# Altium schematic sheet USB_Input.SchDoc
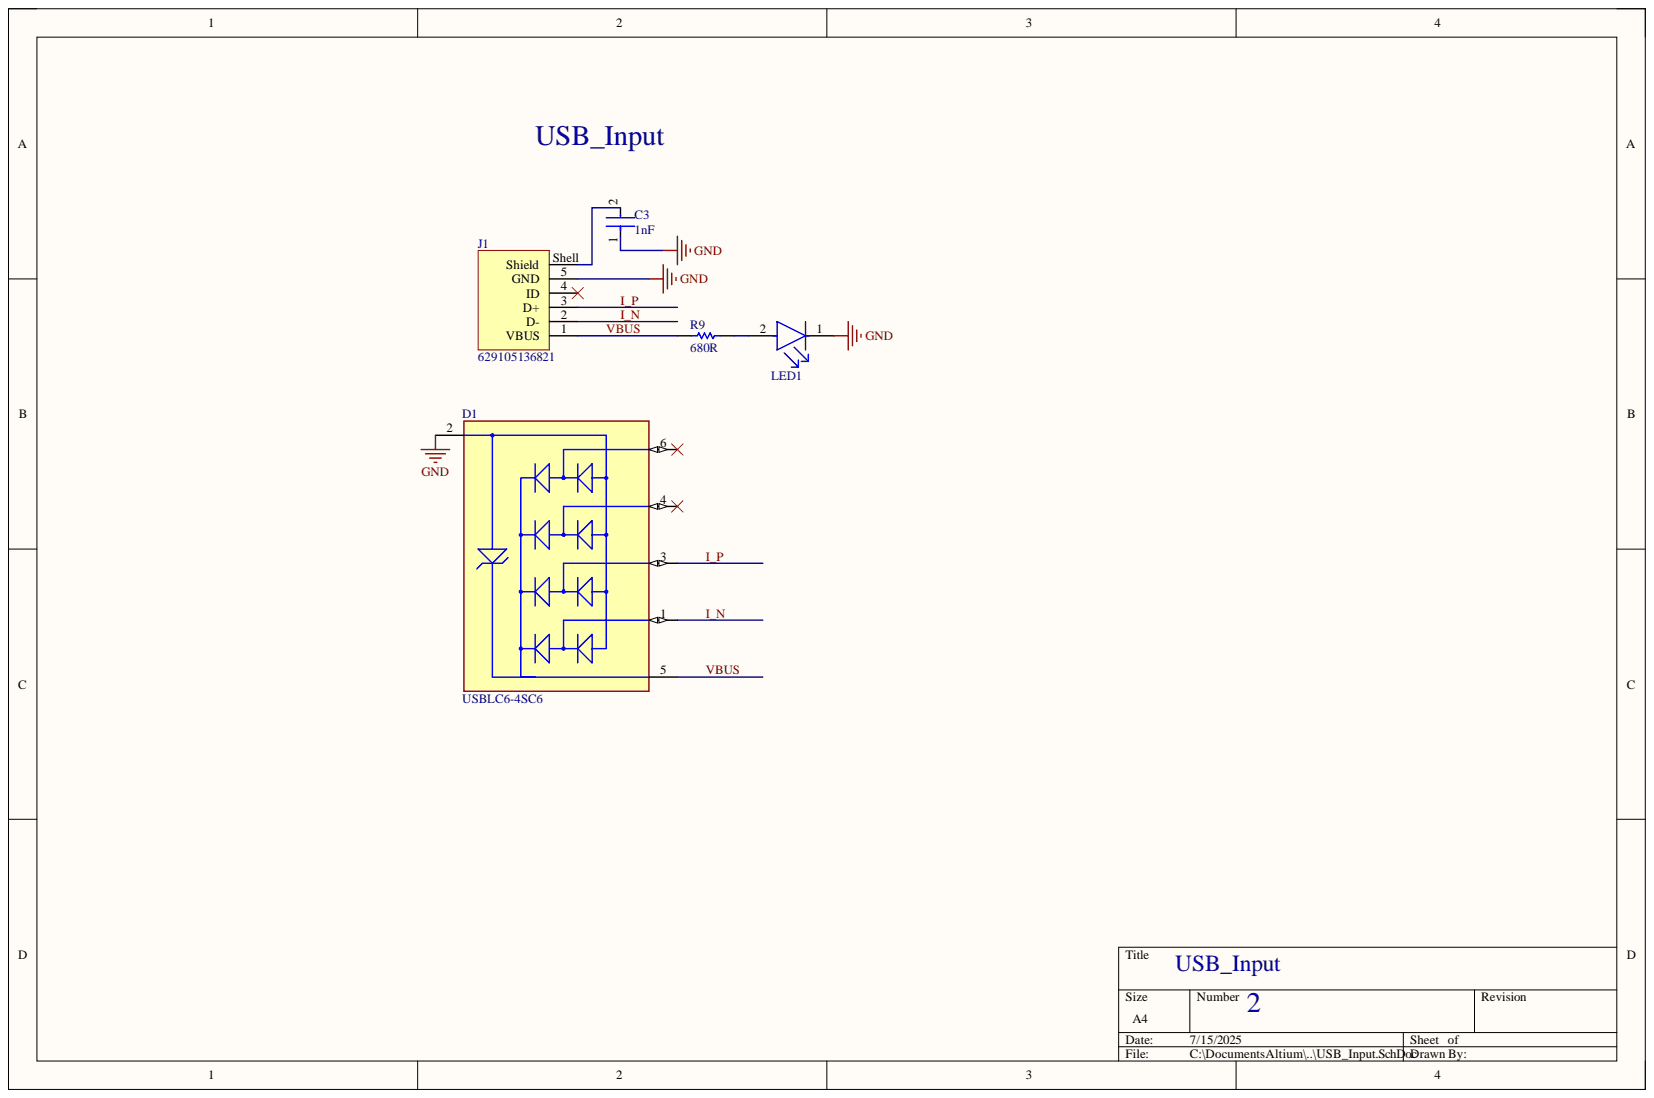
[diagram p0: USB_Input.SchDoc]

## Parts
C3  1nF  [FP-CC0603-DA-MFG]
D1  USBLC6-4SC6  [FP-SOT23-6L-MFG]
J1  629105136821  [629105136821]
LED1  LS R976-NR-1  [FP-LS_R976-MFG]  (value not drawn)
R9  680R  [RESC1608X55X30ML20T20]

## Nets
GND: C3.1 D1.2 J1.5(GND) LED1.1
I_N: D1.1 J1.2(D-)
I_P: D1.3 J1.3(D+)
VBUS: D1.5 J1.1(VBUS) R9.2
NetC3_2: C3.2 J1.Shell(Shield)
NetLED1_2: LED1.2 R9.1

Not connected: D1 4 6; J1 4(ID)

## Library data of the parts
C3 = CMP-2100-03599-2: CAP CER 1000PF 50V X7R 0603; Manufacturer: YAGEO; Manufacturer Part Number: CC0603KRX7R9BB102; Capacitance: 1.0 nF; Material: Ceramic; Temperature Coefficient: 15%; Tolerance: 0.1; Value: 1.0 nF; Automotive: No; Category: Cap; Component Kind: Standard; ComponentLink2Description: Datasheet; ComponentLink2URL: http://www.yageo.com/documents/recent/UPY-GPHC_X7R_6.3V-to-50V_18.pdf; Device Class L1: Passive Components; Device Class L2: Capacitors; Device Class L3: Ceramic Capacitors; Digikey Description: CAP CER 1000PF 50V X7R 0603; Footprint Url: http://www.yageo.com/exep/pages/download/literatures/UPY-C_GEN_24.pdf; Height: 0.9mm; Lead Free: Yes; Library Name: Altium Content Vault; Mouser Description: Multilayer Ceramic Capacitors MLCC - SMD/SMT 1.0nF 50V X7R 10%; Octopart: https://octopart.com/cc0603krx7r9bb102-yageo-192933; Package: CC0603-DA; RoHS: TRUE; Temperature: -55°C to +125°C; Temperature Characteristic: X7R; Temperature Range High: +125°C; Temperature Range Low: -55°C; Voltage: 50 V; Voltage Rating: 50 V
D1 = CMP-2000-07370-2: TVS DIODE 5.25V 17V SOT23-6; Manufacturer: STMicroelectronics; Breakdown Voltage: 6V; Capacitance: 3pF; Direction: Unidirectional; Interface: USB,Ethernet,Other; Max Junction Temp: +125°C; Number Of Channels: 4; Peak Pulse Power: 130W; Standoff Height: 0mm; Value: 3pF; Automotive: No; Category: Diode; Clamping Voltage: 17V; Component Kind: Standard; ComponentLink2Description: Datasheet; ComponentLink2URL: https://upverter.com/datasheet/23dc4ffb028d868909e80577610284b194f13213.pdf; Device Class L1: Circuit Protection; Device Class L2: ESD and Circuit Protection ICs; Device Class L3: unset; Digikey Description: TVS DIODE 5.25V 17V SOT23-6; Height: 1.45mm; Lead Free: Yes; Library Name: Altium Content Vault; Manufacturer Part Number: USBLC6-4SC6; Mouser Description: USBLC6 Series 4 Line 6 V Uni / Bi-Directional ESD Protection - SOT-23-6; Octopart: https://octopart.com/usblc6-4sc6-stmicroelectronics-347147; Package: SOT23-6L; RoHS: TRUE; Temperature: -40°C to +125°C; Temperature Range High: +125°C; Temperature Range Low: -40°C
J1 = CMP-1502-03253-2: NexarPartId: 19991173; Connector Type: USB; Contact Resistance: 30mΩ; Current Rating: 1A; Gender: Receptacle; Insulation Resistance: 1GΩ; Number of Contacts: 5; Number of Ports: 1; Operating Supply Voltage: 30V; Plating: Nickel; USB Standard: USB 2.0; Color: Black; Component Kind: Standard; Contact Material: Copper; Contact Plating: Gold; Flammability Rating: UL94 V-0; Height: 2.9mm; Lead Free: Lead Free; Length: 5.9mm; Library Name: Altium Content Vault; Max Operating Temperature: 105°C; Min Operating Temperature: -40°C; Mount: Horizontal, Surface Mount; Number of Pins: 5; Number of Positions: 5; Orientation: Horizontal; Packaging: Tape and Reel; REACH SVHC: Unknown; RoHS: Compliant; Termination: Solder; Voltage Rating: 30V
LED1 = CMP-62016-000037-1: LED RED DIFFUSED 0805 SMD; Dominant Wavelength: 633nm; Forward Current: 25mA; Forward Voltage: 2.5V; Lens Color: White; Lens Size: 1.25mm; Lens Style: Flat; Luminous Flux: 0.47lm; Luminous Intensity: 180mcd; Number of Elements: 1; Power Dissipation: 65W; Reverse Voltage: 12V; Test Current: 20mA; Viewing Angle: 160°; Case Code (Imperial): 0805; Case Code (Metric): 2012; Case/Package: 0805; Color: Red; Component Kind: Standard; Depth: 1.35mm; Height: 0.9mm; Illumination Color: Red; Length: 2mm; Lens Transparency: Diffused; Library Name: Altium Content Vault; Max Operating Temperature: 85°C; Min Operating Temperature: -30°C; Mount: Surface Mount; Number of LEDs: 1; Number of Pins: 2; Package Quantity: 16000; Packaging: Tape and Reel; Radiation Hardening: No; Width: 1.25mm
R9 = CMP-2000-00114-1: Manufacturer: Panasonic; Part Number: ERA-3AEB681V; Component Kind: Standard; Library Name: Altium Content Vault
